# Altium schematic sheet board_sheet3.SchDoc
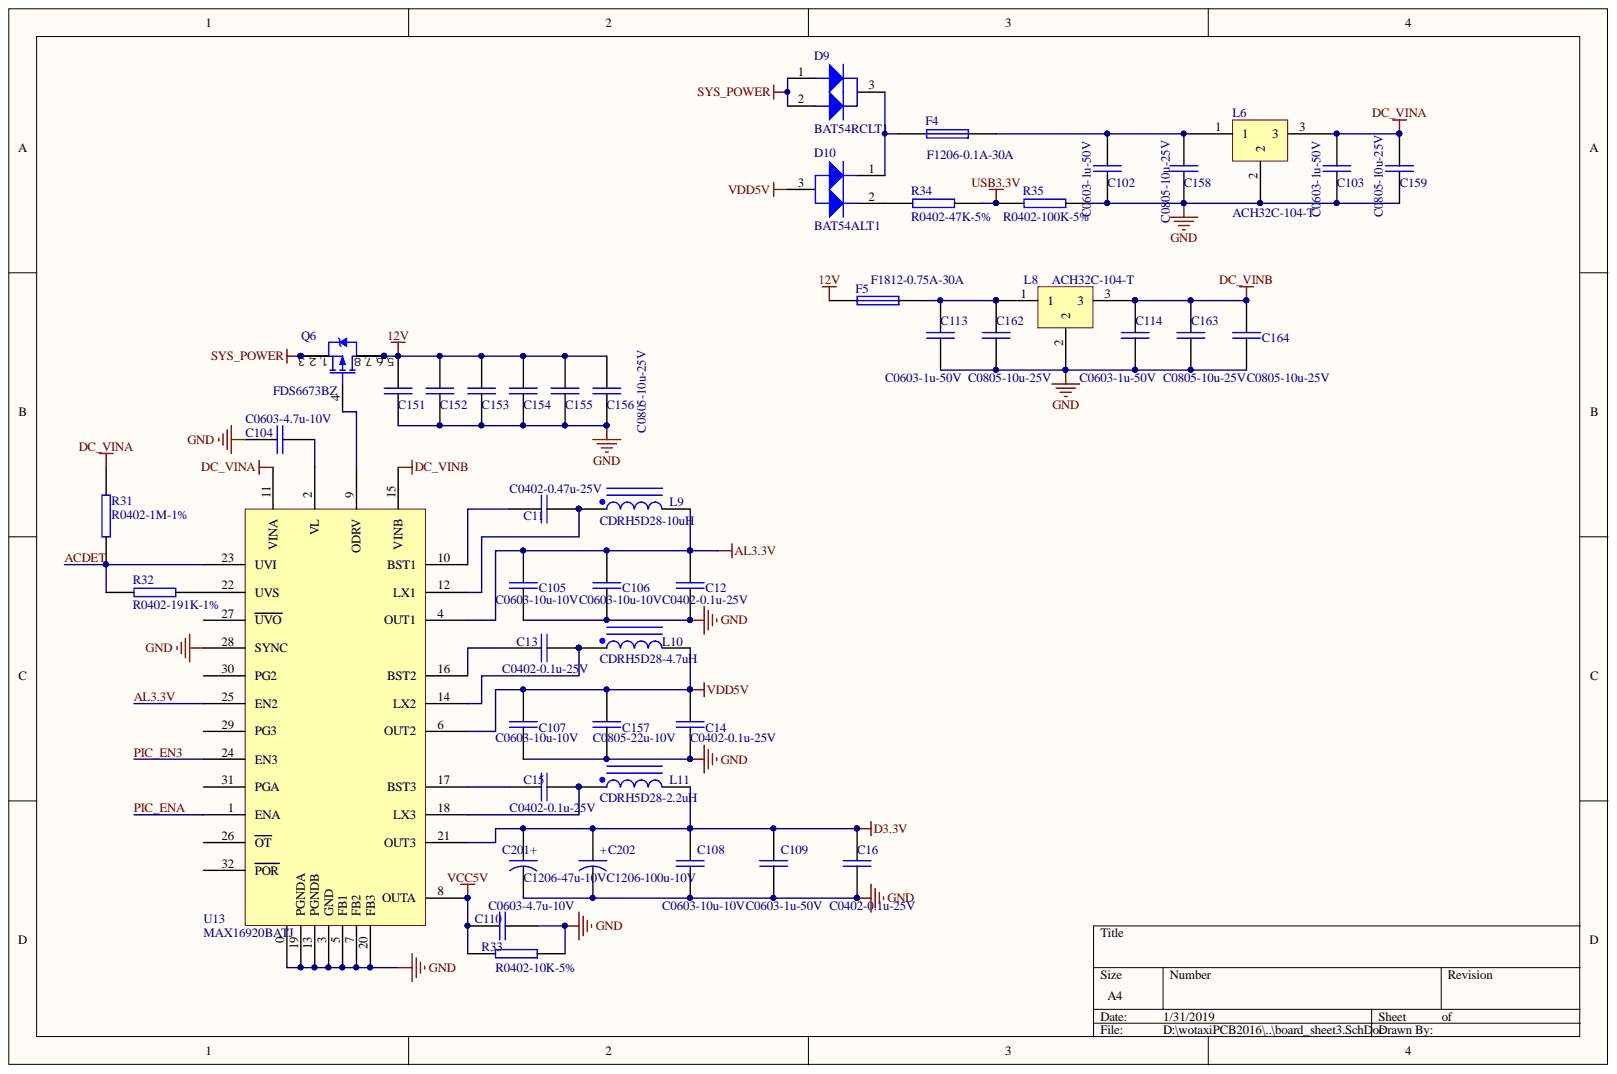
[diagram p0: board_sheet3.SchDoc]

## Parts
C11  C0402-0.47u-25V  [0402]
C12  C0402-0.1u-25V  [0402]
C13  C0402-0.1u-25V  [0402]
C14  C0402-0.1u-25V  [0402]
C15  C0402-0.1u-25V  [0402]
C16  C0402-0.1u-25V  [0402]
C102  C0603-1u-50V  [0603]
C103  C0603-1u-50V  [0603]
C104  C0603-4.7u-10V  [0603]
C105  C0603-10u-10V  [C_0603]
C106  C0603-10u-10V  [C_0603]
C107  C0603-10u-10V  [0603]
C108  C0603-10u-10V  [0603]
C109  C0603-1u-50V  [0603]
C110  C0603-4.7u-10V  [0603]
C113  C0603-1u-50V  [0603]
C114  C0603-1u-50V  [0603]
C151  (no value)  [0805]
C152  (no value)  [0805]
C153  (no value)  [0805]
C154  (no value)  [0805]
C155  (no value)  [0805]
C156  C0805-10u-25V  [0805]
C157  C0805-22u-10V  [0805]
C158  C0805-10u-25V  [0805]
C159  C0805-10u-25V  [0805]
C162  C0805-10u-25V  [0805]
C163  C0805-10u-25V  [0805]
C164  C0805-10u-25V  [0805]
C201  C1206-47u-10V  [3216]
C202  C1206-100u-10V  [3216]
D9  BAT54RCLT1  [SO-G3/C2.5]
D10  BAT54ALT1  [SO-G3/C2.5]
F4  F1206-0.1A-30A  [C3216-1206]
F5  F1812-0.75A-30A  [C4532-1812]
L6  ACH32C-104-T  [ACH32C]
L8  ACH32C-104-T  [ACH32C]
L9  CDRH5D28-10uH  [L5.5*5.5]
L10  CDRH5D28-4.7uH  [L5.5*5.5]
L11  CDRH5D28-2.2uH  [L5.5*5.5]
Q6  FDS6673BZ  [SO-G8/Y2.2]
R31  R0402-1M-1%  [0402]
R32  R0402-191K-1%  [0402]
R33  R0402-10K-5%  [0402]
R34  R0402-47K-5%  [0402]
R35  R0402-100K-5%  [0402]
U13  MAX16920BATJ  [TQFN32]

## Nets
12V: C151.1 C152.1 C153.1 C154.1 C155.1 C156.1 F5.1 Q6.0 Q6.5 Q6.6 Q6.7 Q6.8
D3.3V: C16.1 C108.1 C109.1 C201.1 C202.1 L11.2 U13.21(OUT3)
DC_VINA: C103.1 C159.1 L6.3 R31.2 U13.11(VINA)
DC_VINB: C114.1 C163.1 C164.1 L8.3 U13.15(VINB)
GND: C12.2 C14.2 C16.2 C102.2 C103.2 C104.1 C105.2 C106.2 C107.2 C108.2 C109.2 C110.2 C113.2 C114.2 C151.2 C152.2 C153.2 C154.2 C155.2 C156.2 C157.2 C158.2 C159.2 C162.2 C163.2 C164.2 C201.2 C202.2 L6.2 L8.2 R33.2 R35.2 U13.0(EP) U13.3(GND) U13.5(FB1) U13.7(FB2) U13.13(PGNDB) U13.19(PGNDA) U13.20(FB3) U13.28(SYNC)
SYS_POWER: D9.1 D9.2 Q6.1 Q6.2 Q6.3
USB3.3V: R34.1 R35.1
VCC5V: C110.1 R33.1 U13.8(OUTA)
VDD5V: C14.1 C107.1 C157.1 D10.3 L10.2 U13.6(OUT2)
ACDET: R31.1 R32.1 U13.23(UVI)
AL3.3V: C12.1 C105.1 C106.1 L9.2 U13.4(OUT1) U13.25(EN2)
PIC_EN3: U13.24(EN3)
PIC_ENA: U13.1(ENA)
NetC11_1: C11.1 U13.10(BST1)
NetC11_2: C11.2 L9.1 U13.12(LX1)
NetC13_1: C13.1 U13.16(BST2)
NetC13_2: C13.2 L10.1 U13.14(LX2)
NetC15_1: C15.1 U13.17(BST3)
NetC15_2: C15.2 L11.1 U13.18(LX3)
NetC102_1: C102.1 C158.1 F4.2 L6.1
NetC104_2: C104.2 U13.2(VL)
NetC113_1: C113.1 C162.1 F5.2 L8.1
NetD9_3: D9.3 D10.1 F4.1
NetD10_2: D10.2 R34.2
NetQ6_4: Q6.4 U13.9(ODRV)
NetR32_2: R32.2 U13.22(UVS)

Not connected: U13 26(OT) 27(UVO) 29(PG3) 30(PG2) 31(PGA) 32(POR)

## Library data of the parts
C11-C16 C102-C110 C113 C114 C151-C159 C162-C164 = CAP: Component Kind: Standard; Library Name: KL300-POWER.SCHLIB
C201 C202 = CAP POL: Component Kind: Standard; Library Name: KL300-POWER.SCHLIB
F4 F5 = FUSE: Component Kind: Standard; Library Name: KL300-POWER.SCHLIB
L6 L8 = ACH32C: Component Kind: Standard; Library Name: KL300-POWER.SCHLIB
L9-L11 = INDUCTOR POL: Component Kind: Standard; Library Name: KL300-CONTROLER.SCHLIB
R31-R35 = RES: Component Kind: Standard; Library Name: KL300-POWER.SCHLIB
D9 = BAT54RCLT1: Component Kind: Standard; Library Name: KL300-POWER.SCHLIB
D10 = BAT54ALT1: Component Kind: Standard; Library Name: KL300-CONTROLER.SCHLIB
Q6 = FDS6673BZ: Component Kind: Standard; Library Name: KL300-POWER.SCHLIB
U13 = MAX16920BATJ: Component Kind: Standard; Library Name: KL300-POWER.SCHLIB
